# Altium schematic sheet STM32WL_MCU_IOs.SchDoc
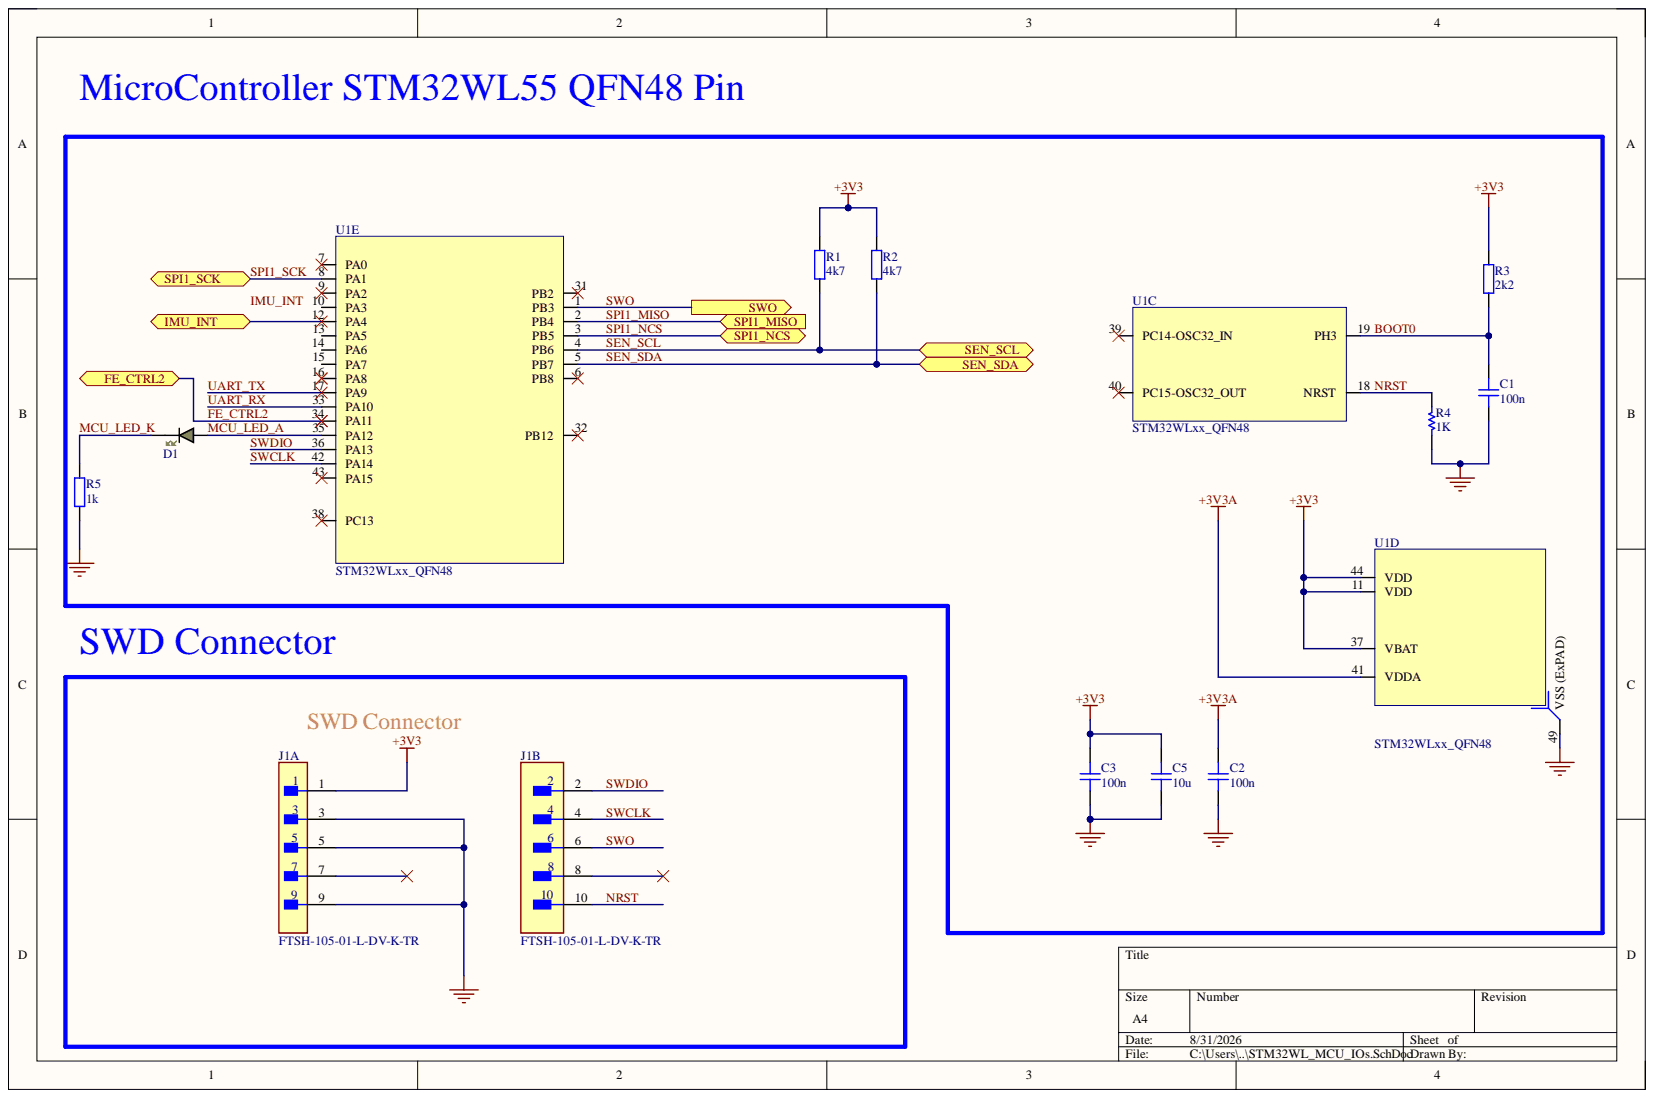
[diagram p0: STM32WL_MCU_IOs.SchDoc]

## Parts
C1  100n  [CAP_0603]
C2  100n  [CAP_0603]
C3  100n  [CAP_0603]
C5  10u  [CAP_0603]
D1  GREEN  [LED_0402_GREEN]  (value not drawn)
J1  FTSH-105-01-L-DV-K-TR  [FP-FTSH-105-01-L-DV-K-TR-MFG]  (parts A,B)
R1  4k7  [RES_0603]
R2  4k7  [RES_0603]
R3  2k2  [RES_0402]
R4  value 1K  [RES_0603]
R5  1k  [RES_0402]
U1  STM32WLxx_QFN48  [STM32WLxx_QFN48]  (parts C,D,E)

## Ports
FE_CTRL2, IMU_INT, SEN_SCL, SEN_SDA, SPI1_MISO, SPI1_NCS, SPI1_SCK, SWO

## Nets
+3V3: C3.2 C5.2 J1.1 R1.2 R2.2 R3.2 U1.11(VDD) U1.37(VBAT) U1.44(VDD)
+3V3A: C2.2 U1.41(VDDA)
GND: C1.1 C2.1 C3.1 C5.1 J1.3 J1.5 J1.9 R4.1 R5.1 U1.49(VSS_(ExPAD))
BOOT0: C1.2 R3.1 U1.19(PH3)
FE_CTRL2: U1.34(PA11) ; port FE_CTRL2
IMU_INT: U1.12(PA4) ; port IMU_INT
MCU_LED_A: D1.A U1.35(PA12)
MCU_LED_K: D1.K R5.2
NRST: J1.10 R4.2 U1.18(NRST)
SEN_SCL: R1.1 U1.4(PB6) ; port SEN_SCL
SEN_SDA: R2.1 U1.5(PB7) ; port SEN_SDA
SPI1_MISO: U1.2(PB4) ; port SPI1_MISO
SPI1_NCS: U1.3(PB5) ; port SPI1_NCS
SPI1_SCK: U1.8(PA1) ; port SPI1_SCK
SWCLK: J1.4 U1.42(PA14)
SWDIO: J1.2 U1.36(PA13)
SWO: J1.6 U1.1(PB3) ; port SWO
UART_RX: U1.33(PA10)
UART_TX: U1.17(PA9)
IMU_INT:

Not connected: J1 7 8; U1 6(PB8) 7(PA0) 9(PA2) 10(PA3) 13(PA5) 14(PA6) 15(PA7) 16(PA8) 31(PB2) 32(PB12) 38(PC13) 39(PC14-OSC32_IN) 40(PC15-OSC32_OUT) 43(PA15)

## Library data of the parts
C1 = CAP_0402_16V: Capacitor SMD 0402 16V; Component Kind: Standard; Library Name: Schematic-Lib-PhilsLab.SchLib
C2 C3 = CAP_0201_16V: Capacitor SMD 0201 16V; Component Kind: Standard; Library Name: Schematic-Lib-PhilsLab.SchLib
C5 = CAP_0603_16V: Capacitor SMD 0603 16V; Component Kind: Standard; Library Name: Schematic-Lib-PhilsLab.SchLib
D1 = LED_0402_GREEN: LED 0402 GREEN SMD; Component Kind: Standard; Library Name: Schematic-Lib-PhilsLab.SchLib
J1 = CMP-2000-06293-2: CONN HEADER SMD 10POS 1.27MM; Connector Type: Male Pin; Current Rating: 1.75A; Gender: Male; Number of Contacts: 10; Row Spacing: 0.05inch; Color: Black; Component Kind: Standard; Contact Material: Bronze; Contact Mating Length: 0.12inch; Contact Plating: Gold; Depth: 3.43mm; Housing Color: Black; Housing Material: Polymer; Lead Free: Lead Free; Length: 6.35mm; Library Name: Altium Content Vault; Max Current Rating: 1.75A; Max Operating Temperature: 125°C; Min Operating Temperature: -55°C; Mount: Surface Mount; Number of Positions: 10; Number of Rows: 2; Orientation: Straight; Package Quantity: 550; Packaging: Tape and Reel; Pitch: 1.27mm; Radiation Hardening: No; REACH SVHC: No SVHC; Termination: Solder
R1 R2 = RES_0603: Resistor SMD 0603; Component Kind: Standard; Library Name: Schematic-Lib-PhilsLab.SchLib
R3 R5 = RES_0402: Resistor SMD 0402; Component Kind: Standard; Library Name: Schematic-Lib-PhilsLab.SchLib
R4 = MCD-00061: Resistor,1K,0402,1%,1/16W; Manufacturer: YAGEO; Manufacturer_Part_Number: RC0402FR-071KL; Database Table Name: MCD_PARTS$; ECCN_EU: NEC; ECCN_US: EAR99; Provided_By: SUBCO; Component Kind: Standard; ComponentLink1Description: Datasheet; ComponentLink1URL: http://tunmcdsvn.tun.st.com/Component_Datasheet_Library/Trunk/Resistors/Yageo_Resistor_RC0402-series; Internal_Part_Number: MCD-00061; Library Name: MCD_PARTS_database.DbLib; Package: R0402; Part_Type: Resistor; Status: Released
U1 = STM32WLxx_QFN48: HQDC : Verified April, 25 2019; Manufacturer: STMicroelectronics; Manufacturer_Part_Number: STM32WLE5CC; Component Kind: Standard; Library Name: MB1789_HP.SCHLIB
